# Altium schematic sheet RPI_SHUTDOWN.SchDoc
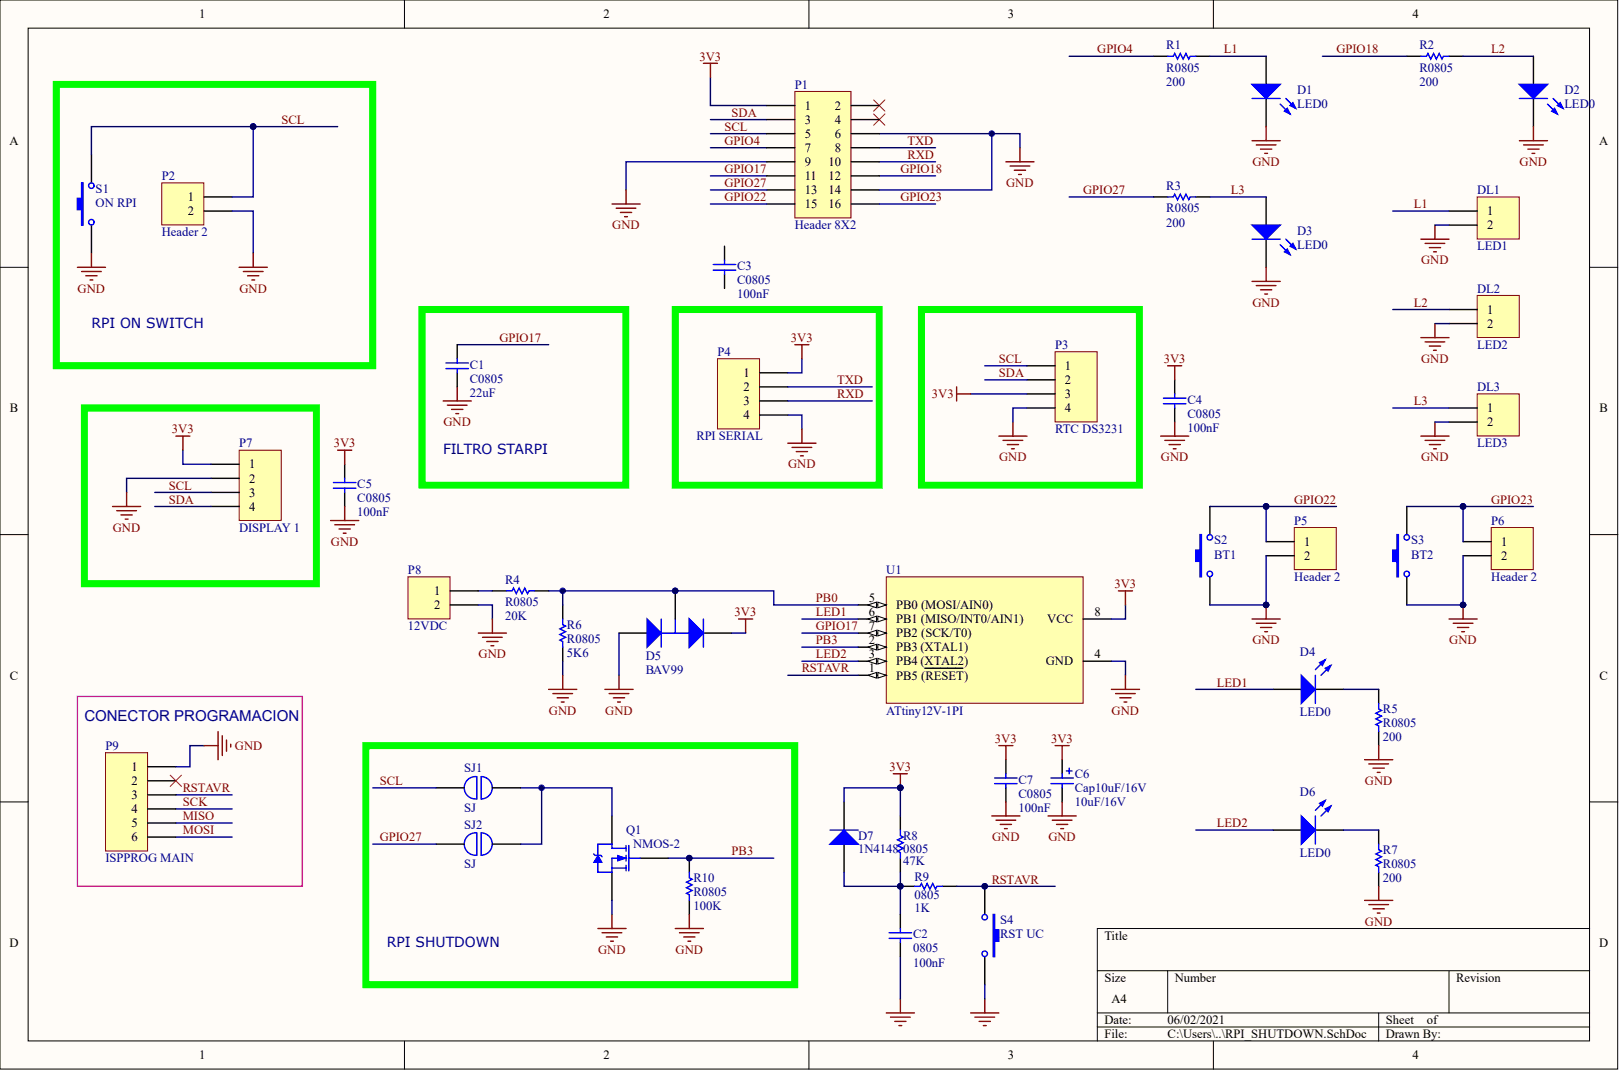
[diagram p0: RPI_SHUTDOWN.SchDoc]

## Parts
C1  C0805  value 22uF
C2  0805  value 100nF
C3  C0805  value 100nF
C4  C0805  value 100nF
C5  C0805  value 100nF
C6  Cap10uF/16V  value 10uF/16V  PackageReference: C0805
C7  C0805  value 100nF
D1  LED0
D2  LED0
D3  LED0
D4  LED0
D5  BAV99
D6  LED0
D7  1N4148
DL1  LED1
DL2  LED2
DL3  LED3
P1  Header 8X2
P2  Header 2
P3  RTC DS3231
P4  RPI SERIAL
P5  Header 2
P6  Header 2
P7  DISPLAY 1
P8  12VDC
P9  ISPPROG MAIN
Q1  NMOS-2
R1  R0805  value 200
R2  R0805  value 200
R3  R0805  value 200
R4  R0805  value 20K
R5  R0805  value 200
R6  R0805  value 5K6
R7  R0805  value 200
R8  0805  value 47K
R9  0805  value 1K
R10  R0805  value 100K
S1  ON RPI
S2  BT1
S3  BT2
S4  RST UC
SJ1  SJ
SJ2  SJ
U1  ATtiny12V-1PI

## Nets
3V3: C4.2 C5.2 C6.1 C7.2 D5.2 D7.2 P1.1 P3.3 P4.1 P7.1 R8.2 U1.8(VCC)
GND: C1.1 C2.1 C4.1 C5.1 C6.2 C7.1 D1.2 D2.2 D3.2 D5.1 DL1.2 DL2.2 DL3.2 P1.6 P1.9 P1.14 P2.2 P3.4 P4.4 P5.2 P6.2 P7.2 P8.2 P9.1 Q1.3 R5.1 R6.1 R7.1 R10.1 S1.2 S2.2 S3.2 S4.2 U1.4(GND)
GPIO4: P1.7 R1.2
GPIO17: C1.2 P1.11 U1.7(PB2_(SCK/T0))
GPIO18: P1.12 R2.2
GPIO22: P1.15 P5.1 S2.1
GPIO23: P1.16 P6.1 S3.1
GPIO27: P1.13 R3.2 SJ2.1
L1: D1.1 DL1.1 R1.1
L2: D2.1 DL2.1 R2.1
L3: D3.1 DL3.1 R3.1
LED1: D4.1 U1.6(PB1_(MISO/INT0/AIN1))
LED2: D6.1 U1.3(PB4_(XTAL2))
MISO: P9.5
MOSI: P9.6
PB0: D5.3 R4.2 R6.2 U1.5(PB0_(MOSI/AIN0))
PB3: Q1.1 R10.2 U1.2(PB3_(XTAL1))
RSTAVR: P9.3 R9.1 S4.1 U1.1(PB5_(RESET))
RXD: P1.10 P4.3
SCK: P9.4
SCL: P1.5 P2.1 P3.1 P7.3 S1.1 SJ1.1
SDA: P1.3 P3.2 P7.4
TXD: P1.8 P4.2
NetC2_2: C2.2 D7.1 R8.1 R9.2
NetD4_2: D4.2 R5.2
NetD6_2: D6.2 R7.2
NetP8_1: P8.1 R4.1
NetQ1_2: Q1.2 SJ1.2 SJ2.2

Not connected: C3 1 2; P1 2 4; P9 2

## Library data of the parts
C1 C3-C5 C7 = (no symbol name): Part: 399-1170-1-ND; Proveedor: Digikey; Published: 8-Jun-2000; LatestRevisionDate: 17-Jul-2002; LatestRevisionNote: Re-released for DXP Platform.; PackageReference: C1206; Price: 0.10; Publisher: Altium Limited
C6 = (no symbol name): Part: 511-1473-1-ND; Proveedor: Digikey; Published: 8-Jun-2000; LatestRevisionDate: 17-Jul-2002; LatestRevisionNote: Re-released for DXP Platform.; Price: 0.65; Publisher: Altium Limited
D7 = (no symbol name): Manufacturer: Generic Components; Class I: Discrete; Class II: Diode - Switching; Published: 8-Jun-2000; Revision: July-2002: Re-released for DXP Platform.; Publisher: Altium Limited
Q1 = (no symbol name): Part: FDN357NCT-ND; Note: Pin 2 is electrically connected to pin 4.; Proveedor: Digikey; Published: 8-Jun-2000; LatestRevisionDate: 17-Jul-2002; LatestRevisionNote: Re-released for DXP Platform.; DatasheetDocument: PD-9.814; PackageReference: TO-220AB; Code_JEDEC: TO-220-AB; ComponentLink1Description: Manufacturer Link; ComponentLink1URL: http://www.irf.com/; Price: 0.56; Publisher: Altium Limited
R1-R3 = (no symbol name): Part: 541-200ACT; Proveedor: Digikey; Value: 200; Published: 8-Jun-2000; LatestRevisionDate: 17-Jul-2002; LatestRevisionNote: Re-released for DXP Platform.; PackageReference: AXIAL-0.5; Price: 0.1; Publisher: Altium Limited
R4 R6 = (no symbol name): Part: 311-1.0MARCT-ND; Proveedor: Digikey; Published: 8-Jun-2000; LatestRevisionDate: 17-Jul-2002; LatestRevisionNote: Re-released for DXP Platform.; PackageReference: AXIAL-0.5; Price: 0.1; Publisher: Altium Limited
R5 R7 = (no symbol name): Part: 541-200ACT; Proveedor: Digikey; Published: 8-Jun-2000; LatestRevisionDate: 17-Jul-2002; LatestRevisionNote: Re-released for DXP Platform.; PackageReference: AXIAL-0.5; Price: 0.1; Publisher: Altium Limited
R8 = (no symbol name): Manufacturer: Generic Components; Class I: Passive; Class II: Resistor; Published: 8-Jun-2000; Revision: July-2002: Re-released for DXP Platform.; Publisher: Altium Limited
R9 = (no symbol name): Manufacturer: Generic Components; Class I: Passive; Class II: Resistor; Value: 1K; Published: 8-Jun-2000; Revision: July-2002: Re-released for DXP Platform.; Publisher: Altium Limited
R10 = (no symbol name): Part: 311-100KARCT-ND; Proveedor: Digikey; Published: 8-Jun-2000; LatestRevisionDate: 17-Jul-2002; LatestRevisionNote: Re-released for DXP Platform.; PackageReference: AXIAL-0.5; Price: 0.1; Publisher: Altium Limited
C2 D1-D6 DL1-DL3 P1-P9 S1-S4 SJ1 SJ2 U1 = (no symbol name)
  C2: Published: 8-Jun-2000; LatestRevisionDate: 17-Jul-2002; LatestRevisionNote: Re-released for DXP Platform.; PackageReference: C1206
  D1-D4 D6: Published: 8-Jun-2000; LatestRevisionDate: 08-Jul-2005; LatestRevisionNote: Mech Layer 1 removed.; PackageReference: LED-0; PackageDescription: LED; 2 Leads
  D5: Published: 21-Mar-2003; DatasheetDocument: Oct-1999; PackageDocument: Sep-2001; PackageReference: SOT-23; ComponentLink1Description: Manufacturer Link; ComponentLink1URL: http://www.infineon.com/; ComponentLink2Description: Datasheet; ComponentLink2URL: http://www.infineon.com/upload/Document/cmc_upload/documents/080/631/bav99series_1.pdf
  DL1-DL3 P1-P9: LatestRevisionDate: 17-Jul-2002; LatestRevisionNote: Re-released for DXP Platform.
  S1-S4: Published: 24-Mar-1999; LatestRevisionDate: 17-Jul-2002; LatestRevisionNote: Re-released for DXP Platform.; PackageReference: SPST-2; PackageDescription: Switch; 2 Leads
  U1: Published: 17-Sep-2003; LatestRevisionDate: 04-Mar-2005; LatestRevisionNote: Stylized 3D Model Added.; DatasheetVersion: 1006C-09/01; PackageVersion: revB, Sep-2001; PackageReference: 8P3; PackageDescription: DIP; 8 Leads; Row Spacing 7.62 mm; Pitch 2.54 mm; ComponentLink1Description: Manufacturer Link; ComponentLink1URL: http://www.atmel.com; ComponentLink2Description: Datasheet; ComponentLink2URL: http://www.atmel.com/dyn/resources/prod_documents/doc1006.pdf
  C2 D1-D6 DL1-DL3 P1-P9 S1-S4 U1: Publisher: Altium Limited
